# Altium schematic sheet Controller_Board_Main.SchDoc
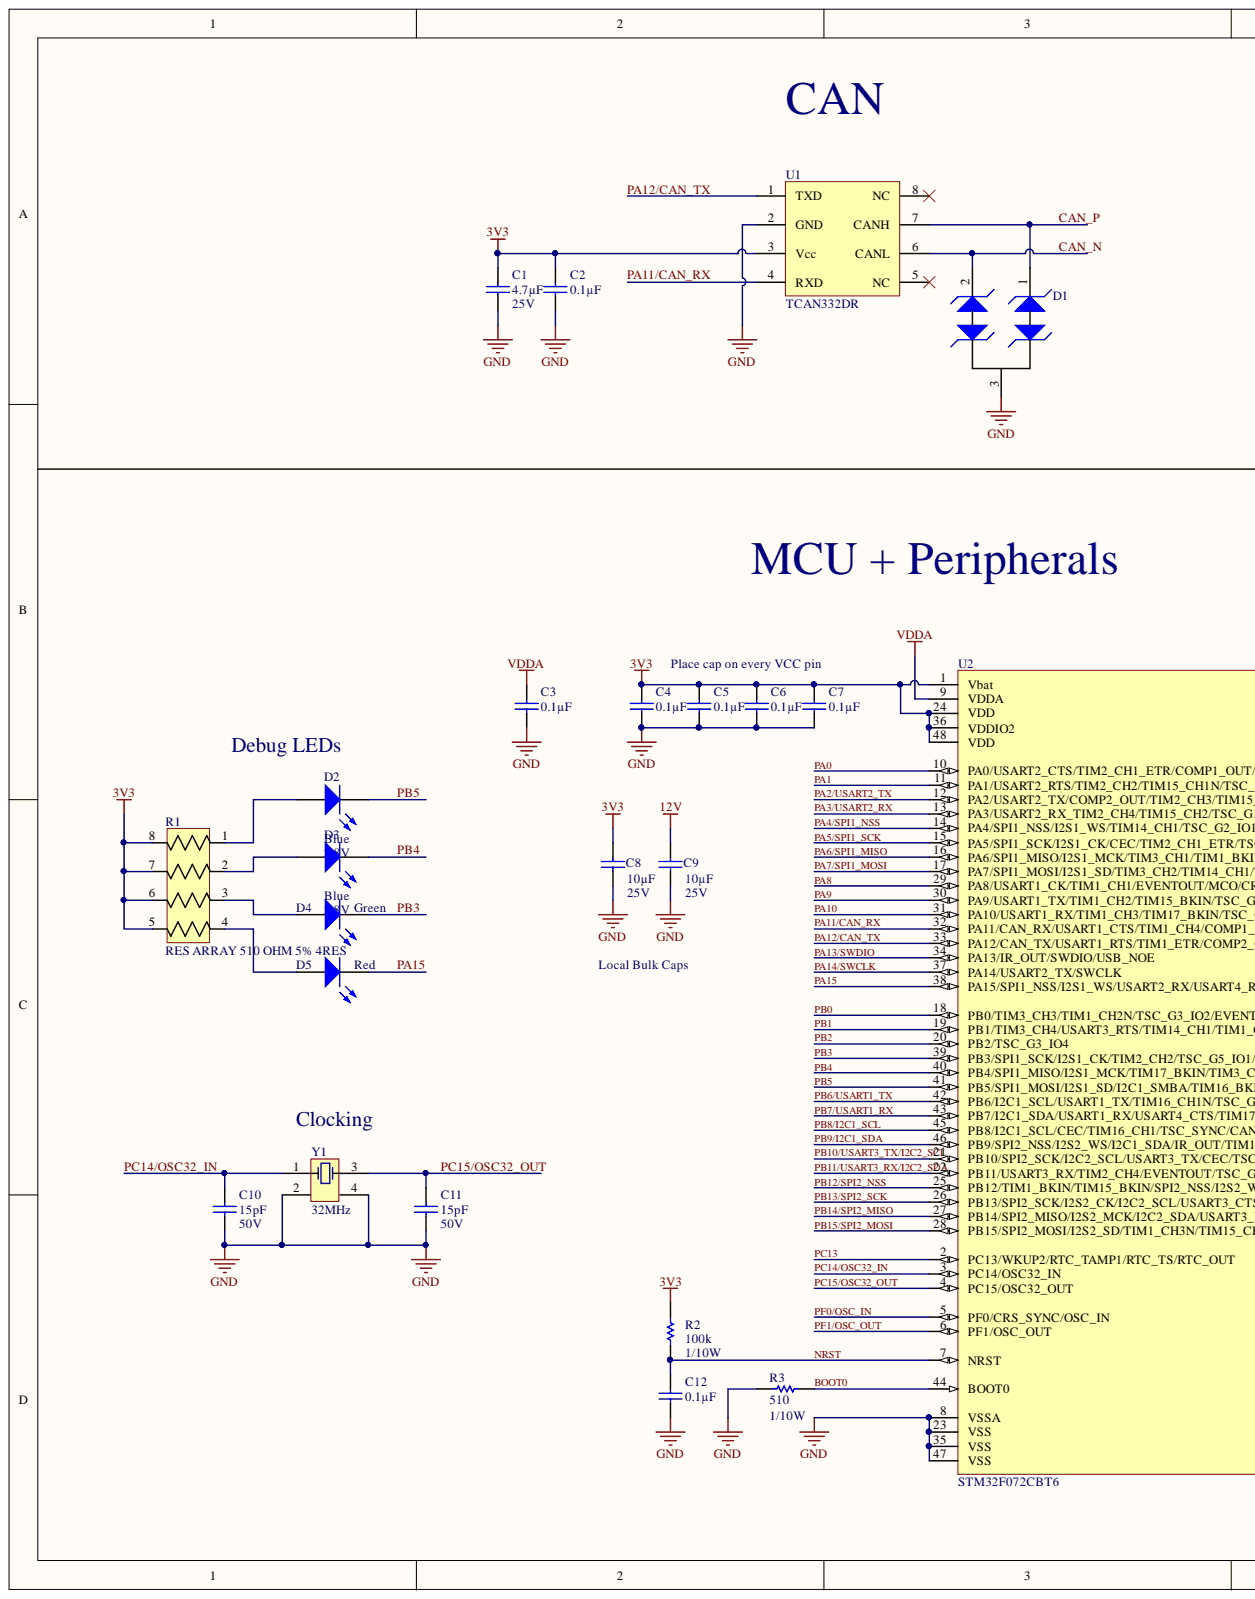
[diagram p0: Controller_Board_Main.SchDoc, left]
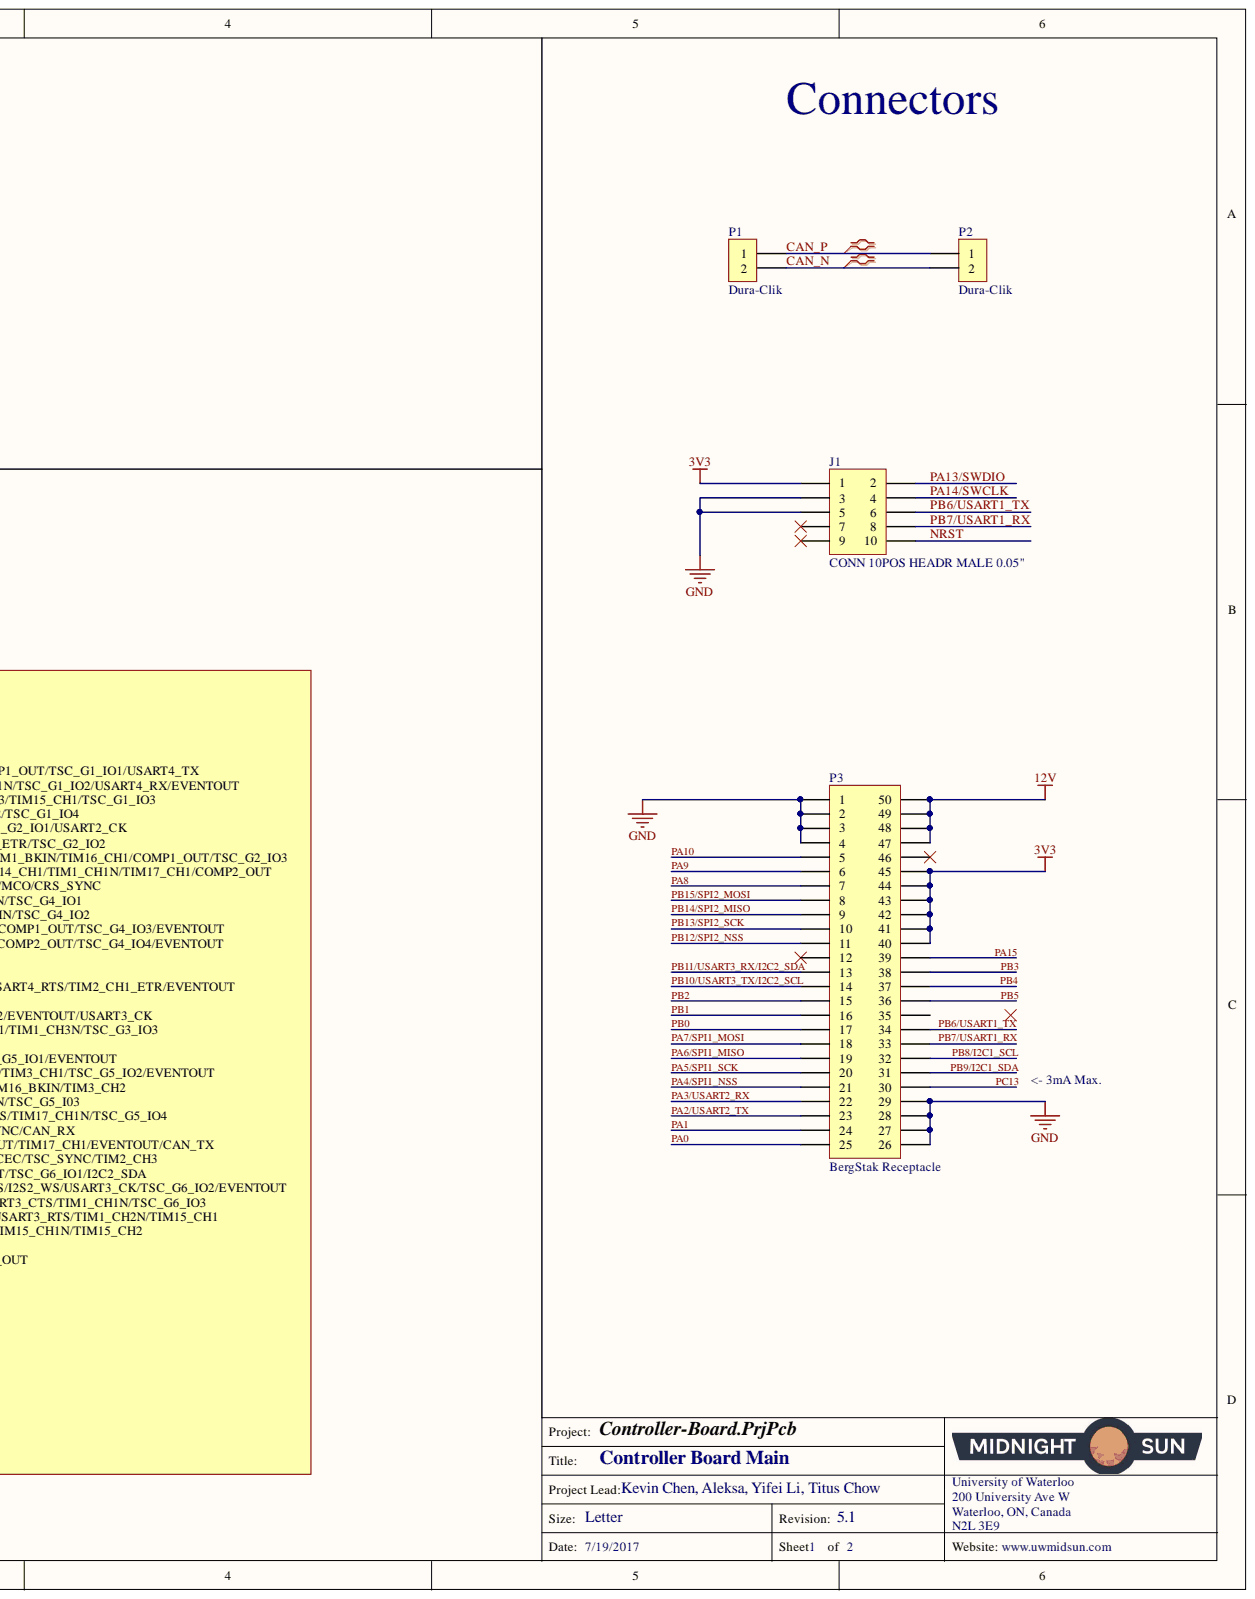
[diagram p1: Controller_Board_Main.SchDoc, right]

## Parts
C1  Capacitance: 4.7µF  Voltage: 25V  [CAP, 0603]  (hidden comment: CAP CER 4.7UF 25V 10% X5R 0603)
C2  Capacitance: 0.1µF  [CAP, 0603]  (hidden comment: CAP CER 0.1UF 50V 10% X7R 0603)
C3  Capacitance: 0.1µF  [CAP, 0603]  (hidden comment: CAP CER 0.1UF 50V 10% X7R 0603)
C4  Capacitance: 0.1µF  [CAP, 0603]  (hidden comment: CAP CER 0.1UF 50V 10% X7R 0603)
C5  Capacitance: 0.1µF  [CAP, 0603]  (hidden comment: CAP CER 0.1UF 50V 10% X7R 0603)
C6  Capacitance: 0.1µF  [CAP, 0603]  (hidden comment: CAP CER 0.1UF 50V 10% X7R 0603)
C7  Capacitance: 0.1µF  [CAP, 0603]  (hidden comment: CAP CER 0.1UF 50V 10% X7R 0603)
C8  Capacitance: 10µF  Voltage - Rated: 25V  [CAP, 0805]  (hidden comment: CAP CER 10uF 25V 10% X5R 0805)
C9  Capacitance: 10µF  Voltage - Rated: 25V  [CAP, 0805]  (hidden comment: CAP CER 10uF 25V 10% X5R 0805)
C10  Capacitance: 15pF  Voltage: 50V  [CAP, 0603]  (hidden comment: CAP CER 15PF 50V ±5% NPO 0603)
C11  Capacitance: 15pF  Voltage: 50V  [CAP, 0603]  (hidden comment: CAP CER 15PF 50V ±5% NPO 0603)
C12  Capacitance: 0.1µF  [CAP, 0603]  (hidden comment: CAP CER 0.1UF 50V 10% X7R 0603)
D1  DIODE TVS 24VWM 70VC SOT23  [SOT23]  (value not drawn)
D2  Color: Blue  [DIOC1608X06N]  (hidden comment: LED BLUE CLEAR 2.8V 0603)
D3  Color: Blue  Voltage - Forward (Vf) (Typ): 2.8V  [DIOC1608X06N]  (hidden comment: LED BLUE CLEAR 2.8V 0603)
D4  Color: Green  [LED_0603]  (hidden comment: LED GREEN CLEAR 2V 0603)
D5  Color: Red  [LED_0603]  (hidden comment: LED RED CLEAR 2V 0603)
J1  CONN 10POS HEADR MALE 0.05"  [CONN, 10POS 0.05" Debug Header]
P1  Dura-Clik  [CONN, 2POS Dura-Click Vertical]
P2  Dura-Clik  [CONN, 2POS Dura-Click Vertical]
P3  Series: BergStak Receptacle  [CONN, Bergstak 50 Pos Receptacle]  (hidden comment: CONN 50POS Bergstak Receptacle 0.02")
R1  RES ARRAY 510 OHM 5% 4RES  [RES ARRAY 4 POS 0804]
R2  Power: 1/10W  Resistance: 100k  [RES, 0603]  (hidden comment: RES 100K OHM 5% 1/8W 0603)
R3  Power: 1/10W  [RES, 0603]  (hidden comment: RES 510 OHM 5% 1/10W 0603)
U1  Manufacturer Part Number: TCAN332DR  [TSOP65P280X145-8N]  (hidden comment: IC CAN Tranceiver TCAN332DR)
U2  Manufacturer Part Number 1: STM32F072CBT6  [TSQFP50P890X890X160-48M]  (hidden comment: IC MCU 32BIT 128KB FLASH 48LQFP)
Y1  Frequency: 32MHz  [CX2520DB CRYSTAL]  (hidden comment: CRYSTAL 32 MHz 8pF 4-SMD)

## Nets
3V3: C1.2 C2.2 C4.2 C5.2 C6.2 C7.2 C8.1 J1.1 P3.40 P3.41 P3.42 P3.43 P3.44 P3.45 R1.5 R1.6 R1.7 R1.8 R2.2 U1.3(Vcc) U2.1(Vbat) U2.24(VDD) U2.36(VDDIO2) U2.48(VDD)
12V: C9.1 P3.47 P3.48 P3.49 P3.50
GND: C1.1 C2.1 C3.1 C4.1 C5.1 C6.1 C7.1 C8.2 C9.2 C10.1 C11.1 C12.1 D1.3 J1.3 J1.5 P3.1 P3.2 P3.3 P3.4 P3.26 P3.27 P3.28 P3.29 R3.1 U1.2(GND) U2.8(VSSA) U2.23(VSS) U2.35(VSS) U2.47(VSS) Y1.2 Y1.4
VDDA: C3.2 U2.9(VDDA)
BOOT0: R3.2 U2.44(BOOT0)
CAN_N: D1.2 P1.2 P2.2 U1.6(CANL)
CAN_P: D1.1 P1.1 P2.1 U1.7(CANH)
NRST: C12.2 J1.10 R2.1 U2.7(NRST)
PA0: P3.25 U2.10(PA0/USART2_CTS/TIM2_CH1_ETR/COMP1_OUT/TSC_G1_IO1/USART4_TX)
PA1: P3.24 U2.11(PA1/USART2_RTS/TIM2_CH2/TIM15_CH1N/TSC_G1_IO2/USART4_RX/EVENTOUT)
PA2/USART2_TX: P3.23 U2.12(PA2/USART2_TX/COMP2_OUT/TIM2_CH3/TIM15_CH1/TSC_G1_IO3)
PA3/USART2_RX: P3.22 U2.13(PA3/USART2_RX_TIM2_CH4/TIM15_CH2/TSC_G1_IO4)
PA4/SPI1_NSS: P3.21 U2.14(PA4/SPI1_NSS/I2S1_WS/TIM14_CH1/TSC_G2_IO1/USART2_CK)
PA5/SPI1_SCK: P3.20 U2.15(PA5/SPI1_SCK/I2S1_CK/CEC/TIM2_CH1_ETR/TSC_G2_IO2)
PA6/SPI1_MISO: P3.19 U2.16(PA6/SPI1_MISO/I2S1_MCK/TIM3_CH1/TIM1_BKIN/TIM16_CH1/COMP1_OUT/TSC_G2_IO3)
PA7/SPI1_MOSI: P3.18 U2.17(PA7/SPI1_MOSI/I2S1_SD/TIM3_CH2/TIM14_CH1/TIM1_CH1N/TIM17_CH1/COMP2_OUT)
PA8: P3.7 U2.29(PA8/USART1_CK/TIM1_CH1/EVENTOUT/MCO/CRS_SYNC)
PA9: P3.6 U2.30(PA9/USART1_TX/TIM1_CH2/TIM15_BKIN/TSC_G4_IO1)
PA10: P3.5 U2.31(PA10/USART1_RX/TIM1_CH3/TIM17_BKIN/TSC_G4_IO2)
PA11/CAN_RX: U1.4(RXD) U2.32(PA11/CAN_RX/USART1_CTS/TIM1_CH4/COMP1_OUT/TSC_G4_IO3/EVENTOUT)
PA12/CAN_TX: U1.1(TXD) U2.33(PA12/CAN_TX/USART1_RTS/TIM1_ETR/COMP2_OUT/TSC_G4_IO4/EVENTOUT)
PA13/SWDIO: J1.2 U2.34(PA13/IR_OUT/SWDIO/USB_NOE)
PA14/SWCLK: J1.4 U2.37(PA14/USART2_TX/SWCLK)
PA15: D5.2 P3.39 U2.38(PA15/SPI1_NSS/I2S1_WS/USART2_RX/USART4_RTS/TIM2_CH1_ETR/EVENTOUT)
PB0: P3.17 U2.18(PB0/TIM3_CH3/TIM1_CH2N/TSC_G3_IO2/EVENTOUT/USART3_CK)
PB1: P3.16 U2.19(PB1/TIM3_CH4/USART3_RTS/TIM14_CH1/TIM1_CH3N/TSC_G3_IO3)
PB2: P3.15 U2.20(PB2/TSC_G3_IO4)
PB3: D4.2 P3.38 U2.39(PB3/SPI1_SCK/I2S1_CK/TIM2_CH2/TSC_G5_IO1/EVENTOUT)
PB4: D3.2 P3.37 U2.40(PB4/SPI1_MISO/I2S1_MCK/TIM17_BKIN/TIM3_CH1/TSC_G5_IO2/EVENTOUT)
PB5: D2.2 P3.36 U2.41(PB5/SPI1_MOSI/I2S1_SD/I2C1_SMBA/TIM16_BKIN/TIM3_CH2)
PB6/USART1_TX: J1.6 P3.34 U2.42(PB6/I2C1_SCL/USART1_TX/TIM16_CH1N/TSC_G5_I03)
PB7/USART1_RX: J1.8 P3.33 U2.43(PB7/I2C1_SDA/USART1_RX/USART4_CTS/TIM17_CH1N/TSC_G5_IO4)
PB8/I2C1_SCL: P3.32 U2.45(PB8/I2C1_SCL/CEC/TIM16_CH1/TSC_SYNC/CAN_RX)
PB9/I2C1_SDA: P3.31 U2.46(PB9/SPI2_NSS/I2S2_WS/I2C1_SDA/IR_OUT/TIM17_CH1/EVENTOUT/CAN_TX)
PB10/USART3_TX/I2C2_SCL: P3.14 U2.21(PB10/SPI2_SCK/I2C2_SCL/USART3_TX/CEC/TSC_SYNC/TIM2_CH3)
PB11/USART3_RX/I2C2_SDA: P3.13 U2.22(PB11/USART3_RX/TIM2_CH4/EVENTOUT/TSC_G6_IO1/I2C2_SDA)
PB12/SPI2_NSS: P3.11 U2.25(PB12/TIM1_BKIN/TIM15_BKIN/SPI2_NSS/I2S2_WS/USART3_CK/TSC_G6_IO2/EVENTOUT)
PB13/SPI2_SCK: P3.10 U2.26(PB13/SPI2_SCK/I2S2_CK/I2C2_SCL/USART3_CTS/TIM1_CH1N/TSC_G6_IO3)
PB14/SPI2_MISO: P3.9 U2.27(PB14/SPI2_MISO/I2S2_MCK/I2C2_SDA/USART3_RTS/TIM1_CH2N/TIM15_CH1)
PB15/SPI2_MOSI: P3.8 U2.28(PB15/SPI2_MOSI/I2S2_SD/TIM1_CH3N/TIM15_CH1N/TIM15_CH2)
PC13: P3.30 U2.2(PC13/WKUP2/RTC_TAMP1/RTC_TS/RTC_OUT)
PC14/OSC32_IN: C10.2 U2.3(PC14/OSC32_IN) Y1.1
PC15/OSC32_OUT: C11.2 U2.4(PC15/OSC32_OUT) Y1.3
PF0/OSC_IN: U2.5(PF0/CRS_SYNC/OSC_IN)
PF1/OSC_OUT: U2.6(PF1/OSC_OUT)
NetD2_1: D2.1 R1.1
NetD3_1: D3.1 R1.2
NetD4_1: D4.1 R1.3
NetD5_1: D5.1 R1.4

Not connected: J1 7 9; P3 12 35 46; U1 5(NC) 8(NC)

## Library data of the parts
C1 = CAP CER 4.7UF 25V 10% X5R 0603: 4.7µF ±10% 25V Ceramic Capacitor X5R 0603 (1608 Metric); Manufacturer 1: Murata Electronics North America; Manufacturer Part Number 1: GRM188R61E475KE11D; Component Kind: Standard; ComponentLink1Description: http://www.murata.com/~/media/webrenewal/support/library/catalog/products/capacitor/mlcc/c02e.ashx; ComponentLink1URL: http://www.murata.com/~/media/webrenewal/support/library/catalog/products/capacitor/mlcc/c02e.ashx; Height - Seated (Max): -; Lead Spacing: -; Lead Style: -; Mounting Type: Surface Mount, MLCC; Operating Temperature: -55°C ~ 85°C; Package / Case: 0603 (1608 Metric); Packaging: Cut Tape (CT); Part Status: Active; Ratings: -; Size / Dimension: 0.063" L x 0.031" W (1.60mm x 0.80mm); Supplier 1: Digi-Key; Supplier Part Number 1: 490-7203-1-ND; Temperature Coefficient: X5R; Thickness (Max): 0.031" (0.80mm); Tolerance: ±10%; Library Name: Schematic Diagrams.SchLib
C2-C7 C12 = CAP CER 0.1UF 50V 10% X7R 0603: 0.10µF ±10% 50V Ceramic Capacitor X7R 0603 (1608 Metric); Manufacturer 1: AVX Corporation; Manufacturer Part Number 1: 06035C104KAT2A; Component Kind: Standard; ComponentLink1Description: http://datasheets.avx.com/X7RDielectric.pdf; ComponentLink1URL: http://datasheets.avx.com/X7RDielectric.pdf; Height - Seated (Max): -; Lead Spacing: -; Lead Style: -; Mounting Type: Surface Mount, MLCC; Operating Temperature: -55°C ~ 125°C; Package / Case: 0603 (1608 Metric); Packaging: Cut Tape (CT); Part Status: Active; Ratings: -; Size / Dimension: 0.063" L x 0.032" W (1.60mm x 0.81mm); Supplier 1: Digi-Key; Supplier Part Number 1: 478-5052-1-ND; Temperature Coefficient: X7R; Thickness (Max): 0.035" (0.90mm); Tolerance: ±10%; Voltage: 50V; Library Name: Schematic Diagrams.SchLib
C8 C9 = CAP CER 10uF 25V 10% X5R 0805: 10µF ±10% 25V Ceramic Capacitor X5R 0805 (2012 Metric); Manufacturer: Murata Electronics North America; Manufacturer Part Number: GRM21BR61E106KA73L; Applications: General Purpose; Category: Capacitors; Component Kind: Standard; ComponentLink1Description: http://search.murata.co.jp/Ceramy/image/img/A01X/G101/ENG/GRM21BR61E106KA73-01.pdf; ComponentLink1URL: http://search.murata.co.jp/Ceramy/image/img/A01X/G101/ENG/GRM21BR61E106KA73-01.pdf; Features: -; Height - Seated (Max): -; Lead Spacing: -; Lead Style: -; Mounting Type: Surface Mount, MLCC; Operating Temperature: -55°C ~ 85°C; Package / Case: 0805 (2012 Metric); Packaging: Cut Tape (CT); Part Status: Active; Pricing 1: 1=0.3, 10=0.214, 100=0.1008, 500=0.07068, 1000=0.05851 (CAD); Ratings: -; RoHS: RoHS Compliant; Size / Dimension: 0.079" L x 0.049" W (2.00mm x 1.25mm); Stock 1: 1695157; Supplier 1: Digi-Key; Supplier Part Number 1: 490-5523-1-ND; Temperature Coefficient: X5R; Thickness (Max): 0.053" (1.35mm); Tolerance: ±10%; Library Name: Schematic Diagrams.SchLib
C10 C11 = CAP CER 15PF 50V ±5% NPO 0603: 15pF ±5% 50V Ceramic Capacitor C0G, NP0 0603 (1608 Metric); Manufacturer 1: Yageo; Manufacturer Part Number 1: CC0603JRNPO9BN150; Component Kind: Standard; ComponentLink1Description: http://www.yageo.com/documents/recent/UPY-GP_NP0_16V-to-50V_16.pdf; ComponentLink1URL: http://www.yageo.com/documents/recent/UPY-GP_NP0_16V-to-50V_16.pdf; Failure Rate: -; Height - Seated (Max): -; Lead Spacing: -; Lead Style: -; Mounting Type: Surface Mount, MLCC; Operating Temperature: -55°C ~ 125°C; Package / Case: 0603 (1608 Metric); Packaging: Cut Tape (CT); Part Status: Active; Ratings: -; Size / Dimension: 0.063" L x 0.031" W (1.60mm x 0.80mm); Supplier 1: Digi-Key; Supplier Part Number 1: 311-1060-1-ND; Temperature Coefficient: C0G, NP0; Thickness (Max): 0.035" (0.90mm); Tolerance: ±5%; Library Name: Schematic Diagrams.SchLib
D1 = DIODE TVS 24VWM 70VC SOT23: TVS DIODE 24VWM 70VC SOT23; Manufacturer 1: Nexperia USA Inc.; Manufacturer Part Number 1: PESD1CAN,215; Capacitance @ Frequency: 11pF @ 1MHz; Current - Peak Pulse (10/1000µs): 3A (8/20µs); Power - Peak Pulse: 200W; Power Line Protection: No; Type: Zener; Voltage - Breakdown (Min): 25.4V; Voltage - Clamping (Max) @ Ipp: 70V; Component Kind: Standard; ComponentLink1Description: http://assets.nexperia.com/documents/data-sheet/PESD1CAN.pdf; ComponentLink1URL: http://assets.nexperia.com/documents/data-sheet/PESD1CAN.pdf; Mounting Type: Surface Mount; Operating Temperature: -65°C ~ 150°C (TA); Package / Case: TO-236-3, SC-59, SOT-23-3; Packaging: Cut Tape (CT); Part Status: Active; Supplier 1: Digi-Key; Supplier Device Package: SOT-23 (TO-236AB); Supplier Part Number 1: 1727-3817-1-ND; Library Name: Schematic Diagrams.SchLib
D2 D3 = LED BLUE CLEAR 2.8V 0603: Blue 470nm LED Indication - Discrete 2.8V 0603 (1608 Metric); Manufacturer 1: Lite-On Inc.; Manufacturer Part Number 1: LTST-C193TBKT-5A; Current - Test: 5mA; Lens Color: Colorless; Lens Style/Size: Rectangle with Flat Top, 1.15mm x 0.80mm; Millicandela Rating: 15mcd; Viewing Angle: 130°; Wavelength - Peak: 468nm; Component Kind: Standard; ComponentLink1Description: http://optoelectronics.liteon.com/upload/download/DS22-2004-060/P_100_LTST-C193TBKT-5A.pdf; ComponentLink1URL: http://optoelectronics.liteon.com/upload/download/DS22-2004-060/P_100_LTST-C193TBKT-5A.pdf; Height (Max): 0.45mm; Lens Transparency: Clear; Mounting Type: Surface Mount; Package / Case: 0603 (1608 Metric); Packaging: Cut Tape (CT); Part Status: Active; Size / Dimension: 1.60mm L x 0.80mm W; Supplier 1: Digi-Key; Supplier Device Package: Chip LED; Supplier Part Number 1: 160-1827-1-ND; Wavelength - Dominant: 470nm; Library Name: Schematic Diagrams.SchLib
  D2: Voltage - Forward (Vf) (Typ): 2.8V
D4 = LED GREEN CLEAR 2V 0603: Green 572nm LED Indication - Discrete 2V 0603 (1608 Metric); Manufacturer: Wurth Electronics Inc.; Manufacturer Part Number: 150060VS75000; Current - Test: 20mA; Lens Color: Colorless; Lens Style/Size: Rectangle with Flat Top, 1.10mm x 0.70mm; Millicandela Rating: 40mcd; Viewing Angle: 140°; Wavelength - Dominant: 570nm; Category: Optoelectronics; Component Kind: Standard; ComponentLink1Description: http://katalog.we-online.de/led/datasheet/150060VS75000.pdf; ComponentLink1URL: http://katalog.we-online.de/led/datasheet/150060VS75000.pdf; Features: -; Height (Max): 0.80mm; Lens Transparency: Clear; Mounting Type: Surface Mount; Package / Case: 0603 (1608 Metric); Packaging: Cut Tape (CT); Part Status: Active; Pricing 1: 1=0.21, 100=0.1617, 500=0.13964 (CAD); RoHS: RoHS Compliant; Size / Dimension: 1.60mm L x 0.80mm W; Stock 1: 9408; Supplier 1: Digi-Key; Supplier Device Package: 0603; Supplier Part Number 1: 732-4980-1-ND; Voltage - Forward (Vf) (Typ): 2V; Wavelength - Peak: 572nm; Library Name: Schematic Diagrams.SchLib
D5 = LED RED CLEAR 2V 0603: Red 631nm LED Indication - Discrete 2V 0603 (1608 Metric); Manufacturer: Wurth Electronics Inc.; Manufacturer Part Number: 150060RS75000; Current - Test: 20mA; Lens Color: Colorless; Lens Style/Size: Rectangle with Flat Top, 1.10mm x 0.70mm; Millicandela Rating: 250mcd; Viewing Angle: 140°; Wavelength - Dominant: 625nm; Wavelength - Peak: 630nm; Category: Optoelectronics; Component Kind: Standard; ComponentLink1Description: http://katalog.we-online.de/led/datasheet/150060RS75000.pdf; ComponentLink1URL: http://katalog.we-online.de/led/datasheet/150060RS75000.pdf; Features: -; Height (Max): 0.80mm; Lens Transparency: Clear; Mounting Type: Surface Mount; Package / Case: 0603 (1608 Metric); Packaging: Cut Tape (CT); Part Status: Active; Pricing 1: 1=0.21, 100=0.1617, 500=0.13964 (CAD); RoHS: RoHS Compliant; Size / Dimension: 1.60mm L x 0.80mm W; Stock 1: 35521; Supplier 1: Digi-Key; Supplier Device Package: 0603; Supplier Part Number 1: 732-4978-1-ND; Voltage - Forward (Vf) (Typ): 2V; Library Name: Schematic Diagrams.SchLib
J1 = CONN 10POS HEADR MALE 0.05": 10 Positions Header Connector 0.050" (1.27mm) Surface Mount Gold; Manufacturer 1: Amphenol FCI; Manufacturer Part Number 1: 20021121-00010C4LF; Contact Length - Mating: 0.120" (3.05mm); Material Flammability Rating: UL94 V-0; Number of Positions: 10; Number of Positions Loaded: All; Shrouding: Unshrouded; Style: Board to Board; Component Kind: Standard; ComponentLink1Description: http://portal.fciconnect.com/Comergent//fci/drawing/20021121.pdf; ComponentLink1URL: http://portal.fciconnect.com/Comergent//fci/drawing/20021121.pdf; Connector Type: Header; Contact Finish - Mating: Gold; Contact Finish - Post: Tin; Contact Finish Thickness - Mating: 10µin (0.25µm); Contact Finish Thickness - Post: 50µin (1.27µm); Contact Length - Post: -; Contact Material: Copper Alloy; Contact Shape: Square; Contact Type: Male Pin; Current Rating: -; Fastening Type: -; Ingress Protection: -; Insulation Color: Black; Insulation Height: -; Insulation Material: Thermoplastic; Mated Stacking Heights: -; Mounting Type: Surface Mount; Number of Rows: 2; Operating Temperature: -; Overall Contact Length: -; Packaging: Cut Tape (CT); Part Status: Active; Pitch - Mating: 0.050" (1.27mm); Row Spacing - Mating: 0.050" (1.27mm); Supplier 1: Digi-Key; Supplier Part Number 1: 609-3695-1-ND; Termination: Solder; Voltage: -; Library Name: Schematic Diagrams.SchLib
P1 P2 = CONN 2POS DURA-CLIK 0.079" VERT: 2 Positions Header, Shrouded Connector 0.079" (2.00mm) Surface Mount, Vertical Tin; Manufacturer: Molex, LLC; Manufacturer Part Number: 5600200220; Mated Stacking Heights: 9.3mm; Number of Positions: 2; Number of Positions Loaded: All; Shrouding: Shrouded - 4 Wall; Style: Board to Cable/Wire; Applications: Automotive, Industrial; Category: Connectors, Interconnects; Component Kind: Standard; ComponentLink1Description: http://www.molex.com/pdm_docs/sd/5600200220_sd.pdf; ComponentLink1URL: http://www.molex.com/pdm_docs/sd/5600200220_sd.pdf; Connector Type: Header; Contact Finish - Mating: Tin; Contact Finish - Post: Tin; Contact Finish Thickness - Mating: -; Contact Finish Thickness - Post: -; Contact Length - Mating: -; Contact Length - Post: -; Contact Material: Copper Alloy; Contact Shape: Square; Contact Type: Male Pin; Current Rating: -; Fastening Type: Latch Lock; Features: Solder Retention; Ingress Protection: -; Insulation Color: Natural; Insulation Height: 0.315" (8.00mm); Insulation Material: Polyamide (PA), Nylon; Material Flammability Rating: -; Mounting Type: Surface Mount; Number of Rows: 1; Operating Temperature: -; Overall Contact Length: -; Packaging: Cut Tape (CT); Part Status: Active; Pitch - Mating: 0.079" (2.00mm); Pricing 1: 1=1.16, 10=1.012, 25=0.952, 50=0.9122, 100=0.8727, 250=0.79336 (CAD); RoHS: RoHS Compliant; Row Spacing - Mating: -; Stock 1: 11912; Supplier 1: Digi-Key; Supplier Part Number 1: WM10862CT-ND; Termination: Solder; Voltage Rating: -; Library Name: Schematic Diagrams.SchLib
P3 = CONN 50POS Bergstak Receptacle 0.02": 50 Position Connector Receptacle, Center Strip Contacts Surface Mount Gold; Manufacturer 1: Amphenol FCI; Manufacturer Part Number 1: 10132798-052100LF; Mated Stacking Heights: 5mm, 5.5mm, 6mm; Number of Positions: 50; Pitch: 0.020" (0.50mm); Component Kind: Standard; ComponentLink1Description: http://portal.fciconnect.com/Comergent//fci/drawing/10132798.pdf; ComponentLink1URL: http://portal.fciconnect.com/Comergent//fci/drawing/10132798.pdf; Connector Type: Receptacle, Center Strip Contacts; Contact Finish: Gold; Contact Finish Thickness: 1µin (0.025µm); Height Above Board: 0.106" (2.70mm); Mounting Type: Surface Mount; Number of Rows: 2; Packaging: Cut Tape (CT); Part Status: Active; Supplier 1: Digi-Key; Supplier Part Number 1: 609-5227-1-ND; Library Name: Schematic Diagrams.SchLib
R1 = RES ARRAY 510 OHM 5% 4RES EXB-N8V511JX: RES ARRAY SMD 510 OHM 5% 4RES EXB-N8V511JX; Manufacturer: Panasonic Electronic Components; Circuit Type: Isolated; Power Per Element: 31mW; Resistance (Ohms): 510; Temperature Coefficient: ±200ppm/°C; Applications: -; Category: Resistors; Component Kind: Standard; ComponentLink1Description: https://industrial.panasonic.com/cdbs/www-data/pdf/AOC0000/AOC0000C14.pdf; ComponentLink1URL: https://industrial.panasonic.com/cdbs/www-data/pdf/AOC0000/AOC0000C14.pdf; Height - Seated (Max): 0.022" (0.55mm); Manufacturer Part Number: EXB-N8V511JX; Mounting Type: Surface Mount; Operating Temperature: -55°C ~ 125°C; Package / Case: 0804, Concave, Long Side Terminals; Packaging: Cut Tape (CT); Part Status: Active; Pricing 1: 1=0.15, 10=0.101, 25=0.0696, 50=0.0544, 100=0.0389, 250=0.03024, 500=0.02404, 1000=0.01706, 5000=0.01319 (CAD); RoHS: RoHS Compliant; Size / Dimension: 0.079" L x 0.039" W (2.00mm x 1.00mm); Stock 1: 25621; Supplier 1: Digi-Key; Supplier Device Package: 0804; Supplier Part Number 1: Y10511CT-ND; Tolerance: ±5%; Library Name: Schematic Diagrams.SchLib
R2 = RES 100K OHM 5% 1/8W 0603: RES SMD 100K OHM 5% 1/10W 0603; Manufacturer 1: Yageo; Manufacturer Part Number 1: RC0603JR-07100KL; Temperature Coefficient: ±100ppm/°C; Component Kind: Standard; ComponentLink1Description: http://www.yageo.com/documents/recent/PYu-RC_Group_51_RoHS_L_7.pdf; ComponentLink1URL: http://www.yageo.com/documents/recent/PYu-RC_Group_51_RoHS_L_7.pdf; Composition: Thick Film; Failure Rate: -; Height - Seated (Max): 0.022" (0.55mm); Operating Temperature: -55°C ~ 155°C; Package / Case: 0603 (1608 Metric); Packaging: Cut Tape (CT); Part Status: Active; Size / Dimension: 0.063" L x 0.031" W (1.60mm x 0.80mm); Supplier 1: Digi-Key; Supplier Device Package: 0603; Supplier Part Number 1: 311-100KGRCT-ND; Tolerance: ±5%; Library Name: Schematic Diagrams.SchLib
R3 = RES 510 OHM 5% 1/10W 0603: RES SMD 510 OHM 5% 1/10W 0603; Manufacturer 1: Yageo; Manufacturer Part Number 1: RC0603JR-07510RL; Resistance: 510; Temperature Coefficient: ±100ppm/°C; Component Kind: Standard; ComponentLink1Description: http://www.yageo.com/documents/recent/PYu-RC_Group_51_RoHS_L_7.pdf; ComponentLink1URL: http://www.yageo.com/documents/recent/PYu-RC_Group_51_RoHS_L_7.pdf; Composition: Thick Film; Failure Rate: -; Height - Seated (Max): 0.022" (0.55mm); Operating Temperature: -55°C ~ 155°C; Package / Case: 0603 (1608 Metric); Packaging: Cut Tape (CT); Part Status: Active; Size / Dimension: 0.063" L x 0.031" W (1.60mm x 0.80mm); Supplier 1: Digi-Key; Supplier Device Package: 0603; Supplier Part Number 1: 311-510GRCT-ND; Tolerance: ±5%; Library Name: Schematic Diagrams.SchLib
U1 = IC CAN Tranceiver TCAN332DR: 1/1 Transceiver CAN 8-SOIC; Component Kind: Standard; Digikey Part Number: 296-43711-1-ND; Supplier 1: Digi-Key; Supplier Part Number 1: 296-43711-1-ND; Library Name: Schematic Diagrams.SchLib
U2 = IC MCU 32BIT 128KB FLASH 48LQFP: ARM® Cortex®-M0 STM32 F0 Microcontroller IC 32-Bit 48MHz 128KB (128K x 8) FLASH 48-LQFP (7x7); Manufacturer 1: STMicroelectronics; Connectivity: CAN, HDMI-CEC, I²C, IrDA, LIN, SPI, UART/USART, USB; Data Converters: A/D 10x12b, D/A 2x12b; Number of I/O: 37; Oscillator Type: Internal; Peripherals: DMA, I²S, POR, PWM, WDT; RAM Size: 16K x 8; Voltage - Supply (Vcc/Vdd): 1.65 V ~ 3.6 V; Component Kind: Standard; ComponentLink1Description: http://www.st.com/content/ccc/resource/technical/document/datasheet/cd/46/43/83/22/d3/40/c8/DM00090510.pdf/files/DM00090510.pdf/jcr:content/translations/en.DM00090510.pdf; ComponentLink1URL: http://www.st.com/content/ccc/resource/technical/document/datasheet/cd/46/43/83/22/d3/40/c8/DM00090510.pdf/files/DM00090510.pdf/jcr:content/translations/en.DM00090510.pdf; Core Processor: ARM® Cortex®-M0; Core Size: 32-Bit; EEPROM Size: -; Operating Temperature: -40°C ~ 85°C (TA); Package / Case: 48-LQFP; Packaging: Tray; Part Status: Active; Program Memory Size: 128KB (128K x 8); Program Memory Type: FLASH; Speed: 48MHz; Supplier 1: Digi-Key; Supplier Device Package: 48-LQFP (7x7); Supplier Part Number 1: 497-14645-ND; Library Name: Schematic Diagrams.SchLib
Y1 = CRYSTAL 32 MHz 8pF 4-SMD: 32MHz ±15ppm Crystal 8pF 50 Ohm -40°C ~ 85°C AEC-Q200 Surface Mount 4-SMD, No Lead; Manufacturer 1: AVX Corp/Kyocera Corp; Manufacturer Part Number 1: CX2520DB32000D0GPSC1; ESR (Equivalent Series Resistance): 50 Ohm; Frequency Stability: ±50ppm; Operating Mode: Fundamental; Component Kind: Standard; ComponentLink1Description: http://media.digikey.com/pdf/Data%20Sheets/AVX%20PDFs/CX2520DB_USY1M-H1-16428-00_Spec.pdf; ComponentLink1URL: http://media.digikey.com/pdf/Data%20Sheets/AVX%20PDFs/CX2520DB_USY1M-H1-16428-00_Spec.pdf; Frequency Tolerance: ±15ppm; Height - Seated (Max): 0.022" (0.55mm); Load Capacitance: 8pF; Mounting Type: Surface Mount; Operating Temperature: -40°C ~ 85°C; Package / Case: 4-SMD, No Lead; Packaging: Cut Tape (CT); Part Status: Active; Ratings: AEC-Q200; Size / Dimension: 0.098" L x 0.079" W (2.50mm x 2.00mm); Supplier 1: Digi-Key; Supplier Part Number 1: 1253-1730-1-ND; Type: MHz Crystal; Library Name: Schematic Diagrams.SchLib
